# Altium schematic sheet Sensor_振动检测传感器.SchDoc
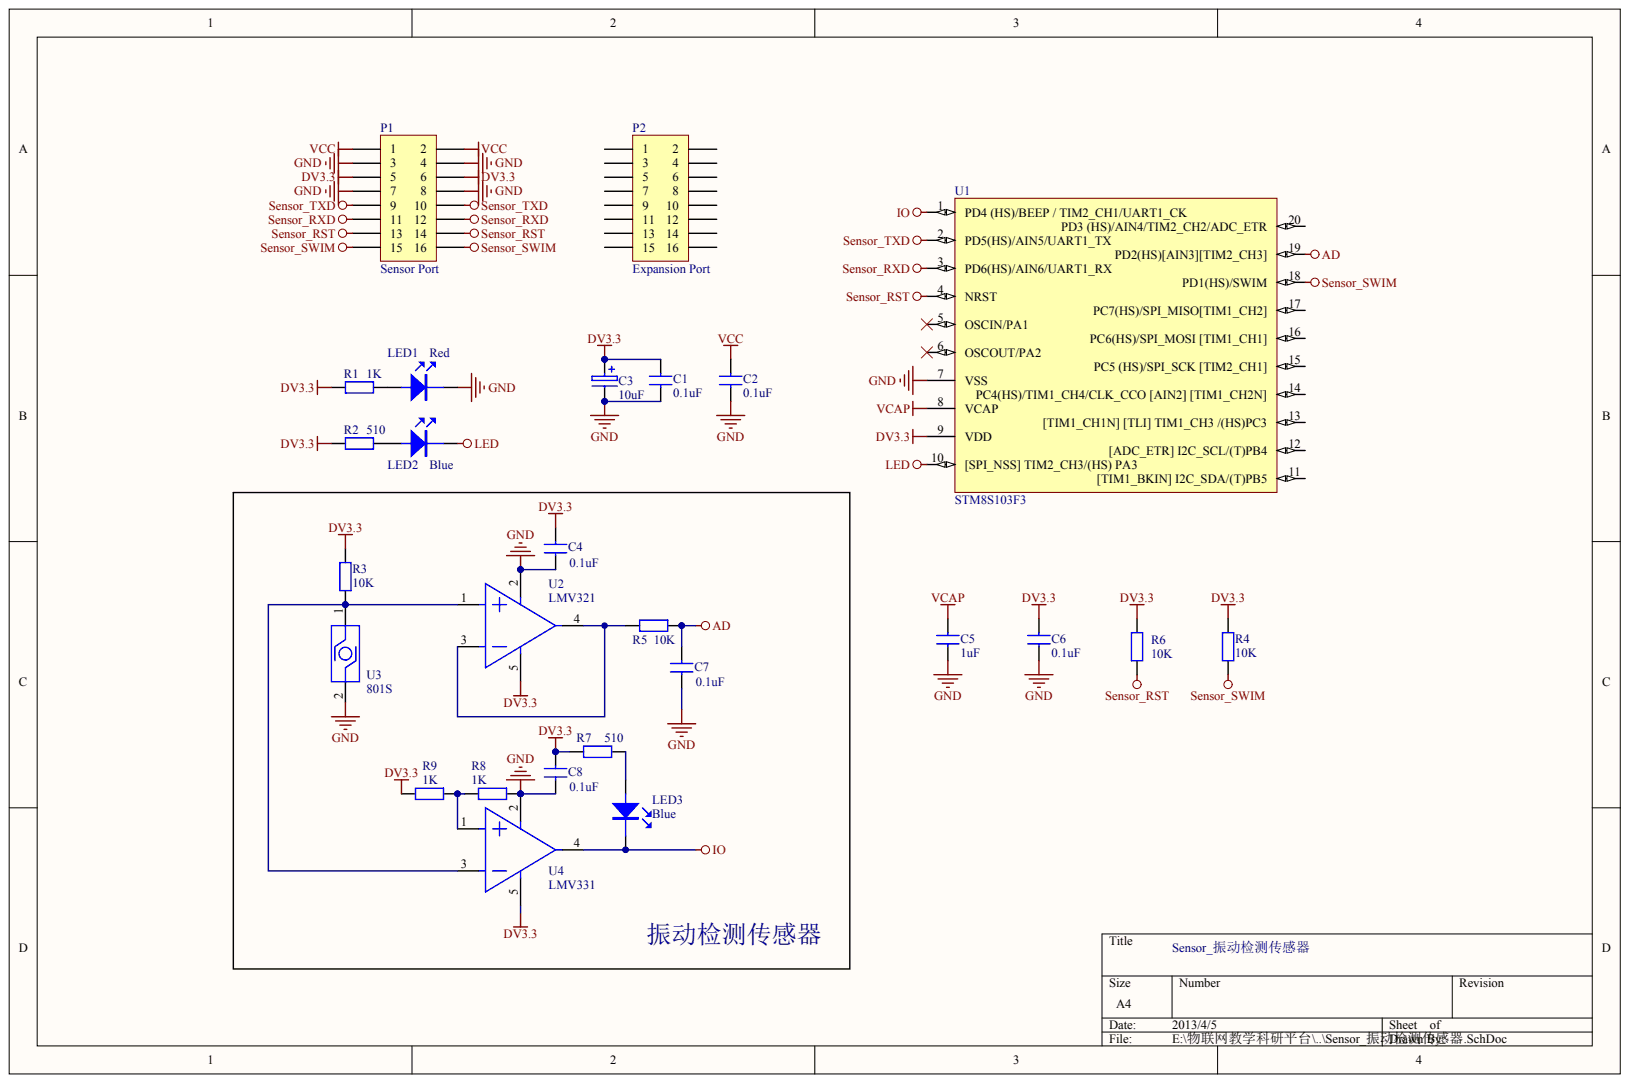
[diagram p0: Sensor_振动检测传感器.SchDoc]

## Parts
C1  0.1uF
C2  0.1uF
C3  10uF
C4  0.1uF
C5  1uF
C6  0.1uF
C7  0.1uF
C8  0.1uF
LED1  Red
LED2  Blue
LED3  Blue
P1  Sensor Port
P2  Expansion Port
R1  1K
R2  510
R3  10K
R4  10K
R5  10K
R6  10K
R7  510
R8  1K
R9  1K
U1  STM8S103F3
U2  LMV321
U3  801S
U4  LMV331

## Nets
AD: C7.1 R5.1 U1.19(PD2(HS)[AIN3][TIM2_CH3])
DV3.3: C1.1 C3.1 C4.1 C6.1 C8.1 P1.5 P1.6 R1.1 R2.2 R3.2 R4.1 R6.2 R7.1 R9.2 U1.9(VDD) U2.5 U4.5
GND: C1.2 C2.2 C3.2 C4.2 C5.1 C6.2 C7.2 C8.2 LED1.2 P1.3 P1.4 P1.7 P1.8 R8.1 U1.7(VSS) U2.2 U3.2 U4.2
IO: LED3.2 U1.1(PD4_(HS)/BEEP_/_TIM2_CH1/UART1_CK) U4.4
LED: LED2.2 U1.10([SPI_NSS]_TIM2_CH3/(HS)_PA3)
SENSOR_RST: P1.13 P1.14 R6.1 U1.4(NRST)
SENSOR_RXD: P1.11 P1.12 U1.3(PD6(HS)/AIN6/UART1_RX)
SENSOR_SWIM: P1.15 P1.16 R4.2 U1.18(PD1(HS)/SWIM)
SENSOR_TXD: P1.9 P1.10 U1.2(PD5(HS)/AIN5/UART1_TX)
VCAP: C5.2 U1.8(VCAP)
VCC: C2.1 P1.1 P1.2
NetLED1_1: LED1.1 R1.2
NetLED2_1: LED2.1 R2.1
NetLED3_1: LED3.1 R7.2
NetR3_1: R3.1 U2.1 U3.1 U4.3
NetR5_2: R5.2 U2.3 U2.4
NetR8_2: R8.2 R9.1 U4.1

Not connected: P2 1 2 3 4 5 6 7 8 9 10 11 12 13 14 15 16; U1 5(OSCIN/PA1) 6(OSCOUT/PA2) 11([TIM1_BKIN]_I2C_SDA/(T)PB5) 12([ADC_ETR]_I2C_SCL/(T)PB4) 13([TIM1_CH1N]_[TLI]_TIM1_CH3_/(HS)PC3) 14(PC4(HS)/TIM1_CH4/CLK_CCO_[AIN2]_[TIM1_CH2N]) 15(PC5_(HS)/SPI_SCK_[TIM2_CH1]) 16(PC6(HS)/SPI_MOSI_[TIM1_CH1]) 17(PC7(HS)/SPI_MISO[TIM1_CH2]) 20(PD3_(HS)/AIN4/TIM2_CH2/ADC_ETR)

## Library data of the parts
C1-C8 LED1-LED3 P1 P2 R1-R9 U1-U4 = (no symbol name)
  P1 P2: LatestRevisionDate: 17-Jul-2002; LatestRevisionNote: Re-released for DXP Platform.
  R6: Value: 10K
  U1: Published: 17-Sep-2003; LatestRevisionDate: 4-Mar-2005; LatestRevisionNote: Stylized 3D Model Added.; DatasheetDocument: 1477C-AVR-09/02; PackageDocument: Aug-1999; PackageReference: 20S; ComponentLink1Description: Manufacturer Link; ComponentLink1URL: http://www.atmel.com; ComponentLink2Description: Datasheet; ComponentLink2URL: http://www.atmel.com/dyn/resources/prod_documents/doc1477.pdf
  P1 P2 U1: Publisher: Altium Limited
